# Altium schematic sheet 05_POWER.SchDoc
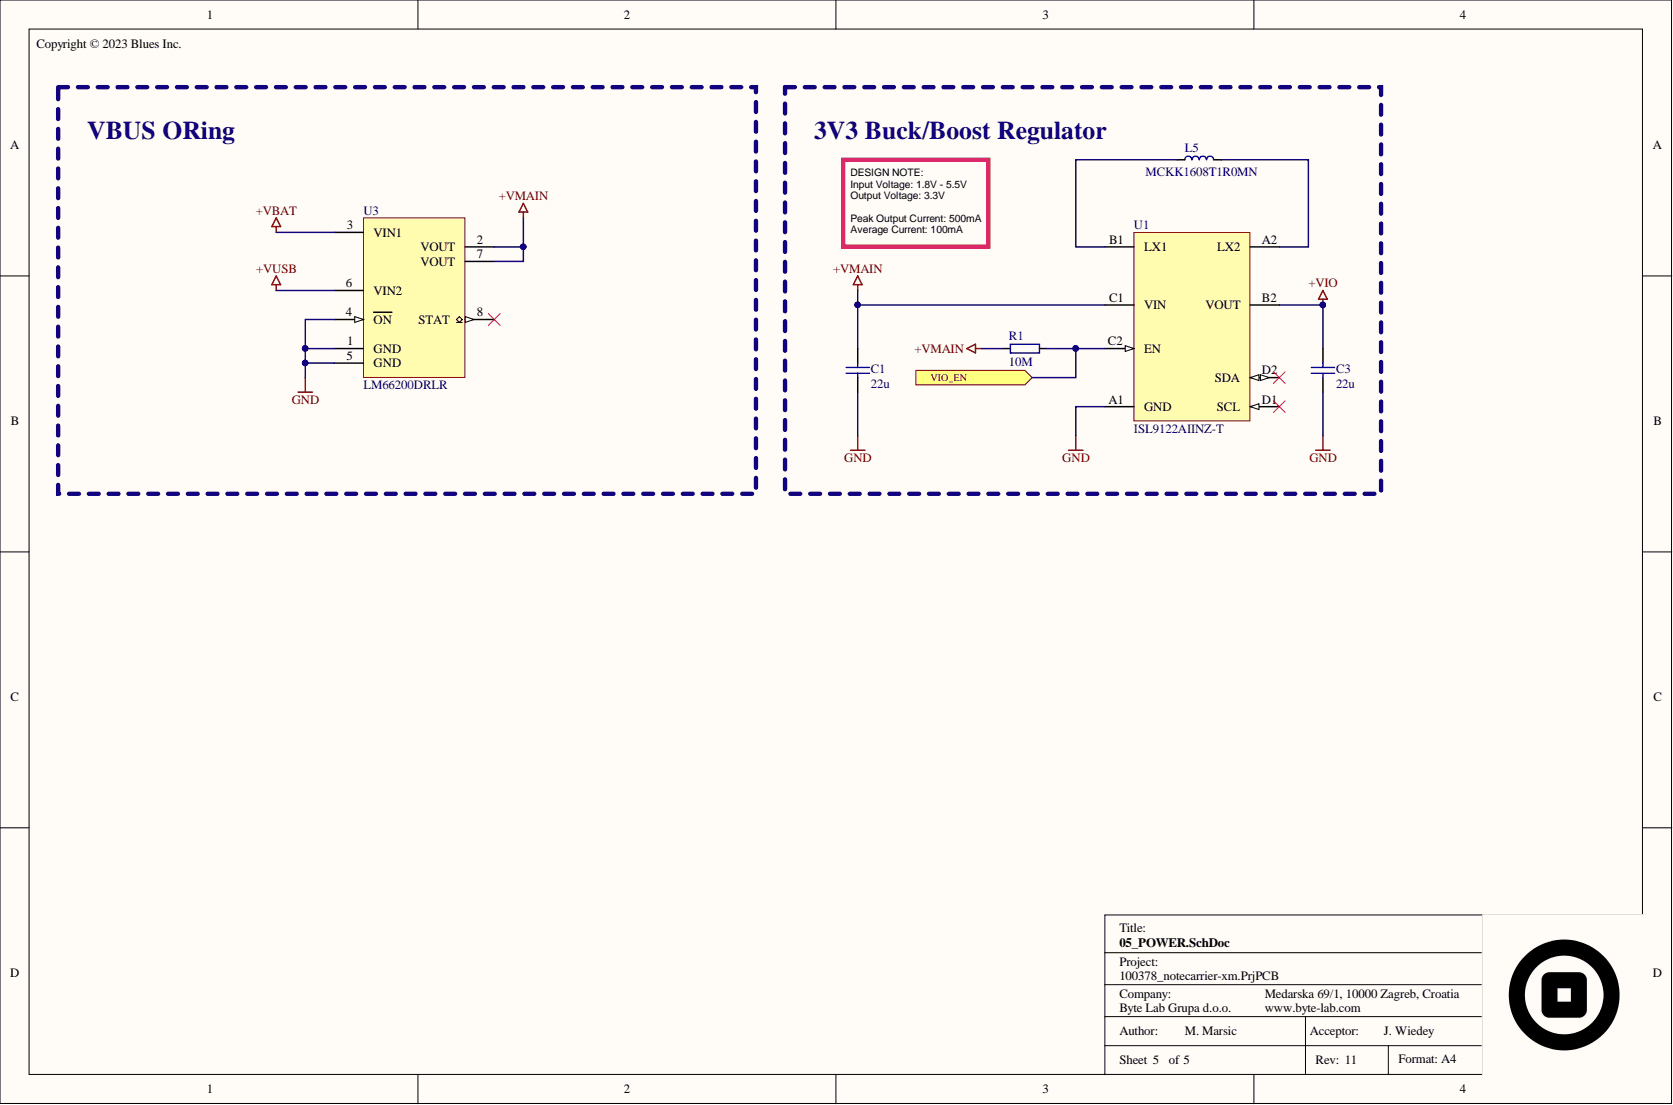
[diagram p0: 05_POWER.SchDoc]

## Parts
C1  22u  [C-0805]
C3  22u  [C-0805]
L5  MCKK1608T1R0MN  [L-0603]
R1  10M  [R-0402]
U1  ISL9122AIINZ-T  [BGA8N40P2X4_180x100x50]
U3  LM66200DRLR  [SOT-583]

## Ports
VIO_EN

## Nets
+VBAT: U3.3(VIN1)
+VIO: C3.2 U1.B2(VOUT)
+VMAIN: C1.2 R1.1 U1.C1(VIN) U3.2(VOUT) U3.7(VOUT)
+VUSB: U3.6(VIN2)
GND: C1.1 C3.1 U1.A1(GND) U3.1(GND) U3.4(ON) U3.5(GND)
VIO_EN: R1.2 U1.C2(EN) ; port VIO_EN
NetL5_1: L5.1 U1.B1(LX1)
NetL5_2: L5.2 U1.A2(LX2)

Not connected: U1 D1(SCL) D2(SDA); U3 8(STAT)

## Library data of the parts
C1 C3 = C0805-22u-16V-X5R: Capacitor ceramic 22u ±10% X5R 16V 0805; BL Part Number: 2006-222; Checked_By: Hamin; Drawn_By: Fumic; Component Kind: Standard; DateModified: 28-07-2022; Library Name: BL_Passive.SchLib; Manufacturer: N/A; Manufacturer Part Number: N/A; Simulation: CAP
L5 = L0603-1u-20 MCKK1608T1R0MN: Inductor 0603 1u ±20% 1.3A; BL Part Number: 2003-989; Manufacturer: Taiyo Yuden; Checked_By: Hlupic; Drawn_By: Fumic; Component Kind: Standard; DateModified: 30-01-2020; Library Name: BL_Passive.SchLib; Manufacturer Part Number: MCKK1608T1R0MN; Supplier 1: Digi-Key; Supplier Part Number 1: 587-5973-1-ND
R1 = R0402-10M-1: Resistor 10M ±1% 0402; BL Part Number: 2002-832; Checked_By: Dodig; Drawn_By: Zvonc; Component Kind: Standard; DateModified: 24-05-2016; Library Name: BL_Passive.SchLib; Manufacturer: N/A; Manufacturer Part Number: N/A; Supplier 1: Digi-Key; Supplier Part Number 1: RC0402FR-0710ML
U1 = ISL9122AIINZ-T: IC CON BUCK-BOOST ADJ 0.5A WLCSP-8; BL Part Number: 2007-147; Manufacturer: Renesas; Checked_By: Hlupic; Drawn_By: Marsic; Component Kind: Standard; DateModified: 13-01-2023; Library Name: BL_Analog.SchLib; Manufacturer Part Number: ISL9122AIINZ-T
U3 = LM66200DRLR: IC, Power switch, OR Controller N+1 ORing Controller N-Channel 2:1 SOT-583; BL Part Number: 2007-318; Manufacturer: Texas Instruments; Checked_By: Hamin; Drawn_By: Golubic; Component Kind: Standard; DateModified: 06-02-2023; Library Name: BL_Analog.SchLib; Manufacturer Part Number: LM66200DRLR; Supplier 1: Digi-Key; Supplier Part Number 1: 296-LM66200DRLRTR-ND
